# Altium schematic sheet Modules.SchDoc(Modules)
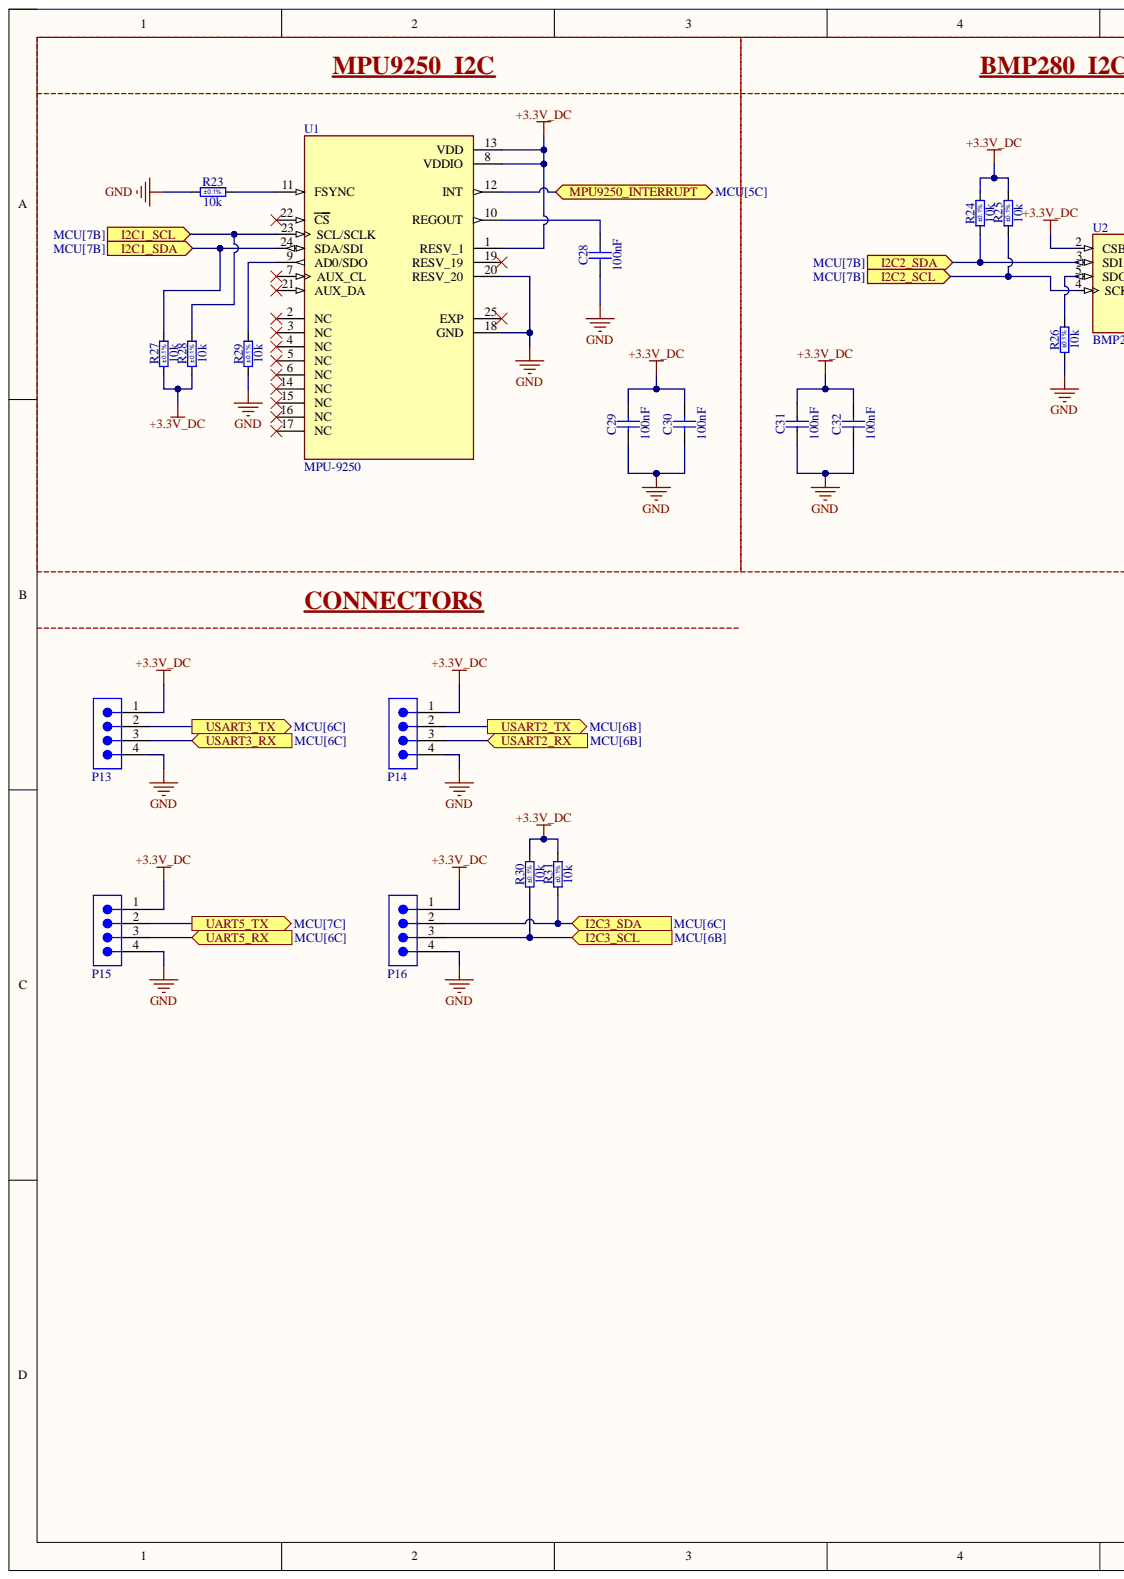
[diagram p0: Modules.SchDoc, left]
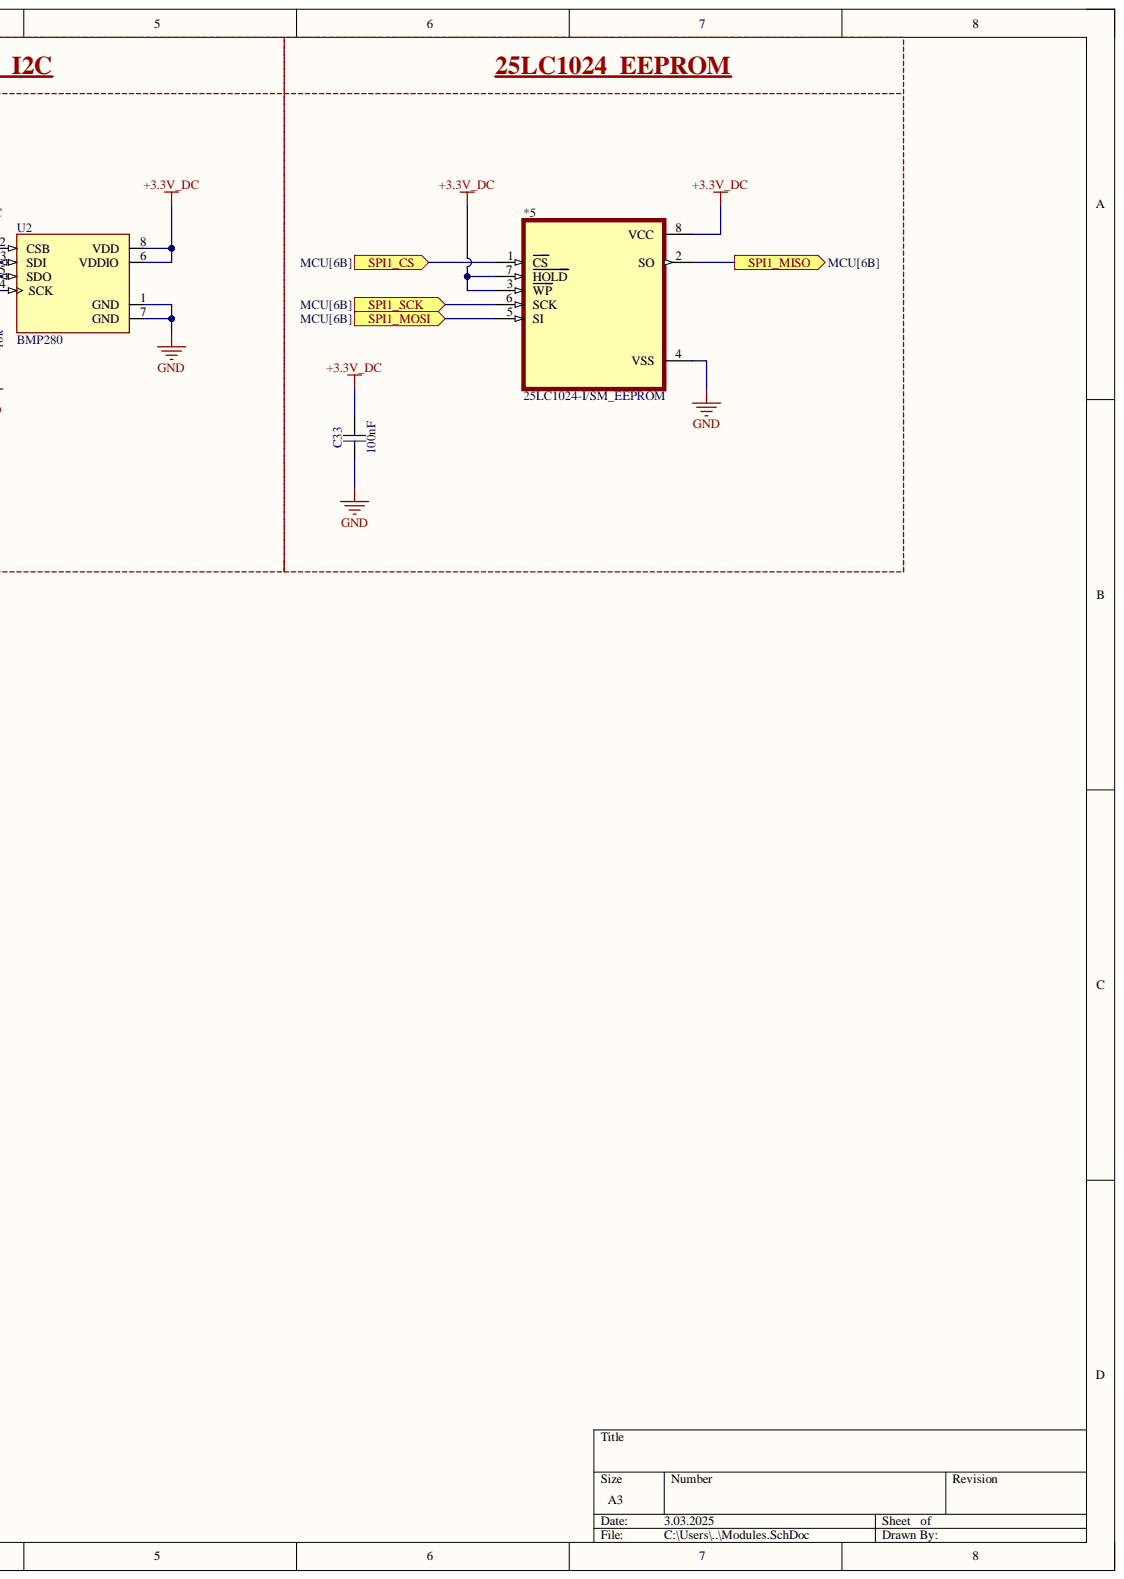
[diagram p1: Modules.SchDoc, right]

## Parts
*5  25LC1024-I/SM_EEPROM  [25LC1024-I/SM_EEPROM]
C28  100nF  [CAPC2012X135N]
C29  100nF  [CAPC2012X135N]
C30  100nF  [CAPC2012X135N]
C31  100nF  [CAPC2012X135N]
C32  100nF  [CAPC2012X135N]
C33  100nF  [CAPC2012X135N]
P13  691322310004  [691322310004]  (value not drawn)
P14  691322310004  [691322310004]  (value not drawn)
P15  691322310004  [691322310004]  (value not drawn)
P16  691322310004  [691322310004]  (value not drawn)
R23  10k  Tolerance: ±0.1%  [RESC2012X60N]
R24  10k  Tolerance: ±0.1%  [RESC2012X60N]
R25  10k  Tolerance: ±0.1%  [RESC2012X60N]
R26  10k  Tolerance: ±0.1%  [RESC2012X60N]
R27  10k  Tolerance: ±0.1%  [RESC2012X60N]
R28  10k  Tolerance: ±0.1%  [RESC2012X60N]
R29  10k  Tolerance: ±0.1%  [RESC2012X60N]
R30  10k  Tolerance: ±0.1%  [RESC2012X60N]
R31  10k  Tolerance: ±0.1%  [RESC2012X60N]
U1  MPU-9250  [QFN40P300X300X105-25N]
U2  BMP280  [XDCR_BMP280]

## Ports
I2C1_SCL, I2C1_SDA, I2C2_SCL, I2C2_SDA, I2C3_SCL, I2C3_SDA, MPU9250_INTERRUPT, SPI1_CS, SPI1_MISO, SPI1_MOSI, SPI1_SCK, UART5_RX, UART5_TX, USART2_RX, USART2_TX, USART3_RX, USART3_TX

## Nets
+3.3V_DC: *5.3(WP) *5.7(HOLD) *5.8(VCC) C29.2 C30.2 C31.2 C32.2 C33.2 P13.1 P14.1 P15.1 P16.1 R24.2 R25.2 R27.1 R28.1 R30.2 R31.2 U1.1(RESV_1) U1.8(VDDIO) U1.13(VDD) U2.2(CSB) U2.6(VDDIO) U2.8(VDD)
GND: *5.4(VSS) C28.1 C29.1 C30.1 C31.1 C32.1 C33.1 P13.4 P14.4 P15.4 P16.4 R23.1 R26.1 R29.1 U1.18(GND) U1.20(RESV_20) U2.1(GND) U2.7(GND)
I2C1_SCL: R28.2 U1.23(SCL/SCLK) ; port I2C1_SCL
I2C1_SDA: R27.2 U1.24(SDA/SDI) ; port I2C1_SDA
I2C2_SCL: R25.1 U2.4(SCK) ; port I2C2_SCL
I2C2_SDA: R24.1 U2.3(SDI) ; port I2C2_SDA
I2C3_SCL: P16.3 R30.1 ; port I2C3_SCL
I2C3_SDA: P16.2 R31.1 ; port I2C3_SDA
MPU9250_INTERRUPT: U1.12(INT) ; port MPU9250_INTERRUPT
SPI1_CS: *5.1(CS) ; port SPI1_CS
SPI1_MISO: *5.2(SO) ; port SPI1_MISO
SPI1_MOSI: *5.5(SI) ; port SPI1_MOSI
SPI1_SCK: *5.6(SCK) ; port SPI1_SCK
UART5_RX: P15.3 ; port UART5_RX
UART5_TX: P15.2 ; port UART5_TX
USART2_RX: P14.3 ; port USART2_RX
USART2_TX: P14.2 ; port USART2_TX
USART3_RX: P13.3 ; port USART3_RX
USART3_TX: P13.2 ; port USART3_TX
NetC28_2: C28.2 U1.10(REGOUT)
NetR23_2: R23.2 U1.11(FSYNC)
NetR26_2: R26.2 U2.5(SDO)
NetR29_2: R29.2 U1.9(AD0/SDO)

Not connected: U1 2(NC) 3(NC) 4(NC) 5(NC) 6(NC) 7(AUX_CL) 14(NC) 15(NC) 16(NC) 17(NC) 19(RESV_19) 21(AUX_DA) 22(CS) 25(EXP)

## Library data of the parts
C28-C33 = CC0805_100NF_50V_10%_X7R: Non-polarised capacitor; Manufacturer: GENERIC; Manufacturer1 Part Number: C2012X7R1H104K085; Part Description: SMD Multilayer Chip Ceramic Capacitor; Database Table Name: Capacitors SMD; TC: X7R; Tolerance: ±10%; Voltage: 50V; Case: 0805; Component Kind: Standard; Component Type: Standard; CreateDate: 3.12.2007; HelpURL: \\cern.ch\dfs\Applications\Altium\Datasheets\CC0805_X7R_TDK_C.pdf; LatestRevisionDate: 22.04.2015; Library Name: Capacitors.DbLib; Manufacturer1 ComponentHeight: 1mm; Manufacturer1 Example: TDK; Manufacturer Part Number: CC0805_100NF_50V_10%_X7R; Mounted: Yes; Part Number: CC0805_100NF_50V_10%_X7R; Pin Count: 2; Sense: No; SMD: Yes; Socket: No; Status: None; Value: 100nF; Author: CERN DEM JLC
P13-P16 = 691322310004: WR-TBL Series 322 Horizontal PCB Header, THT, pitch 3.81mm, 4p; Manufacturer: Wurth Elektronik; Database Table Name: Connectors; Modularity: No; Rated Current: 10A; Working Voltage: 300V; Application: Pluggable; Category: Terminal Blocks; Component Kind: Standard; ComponentLink1Description: Website Link; ComponentLink1URL: https://www.we-online.com/redexpert/article/691322310004?ad; ComponentLink2Description: Datasheet Link; ComponentLink2URL: https://www.we-online.com/redexpert/spec/691322310004?ad; Library Name: WE - Electromechanical Components.DbLib; Manufacturer Part Number: 691322310004; Match Code: WR-TBL; Mount: Through Hole; Number of Pins: 4; Number of Rows: 1; Operating Temperature Max: 105°C; Operating Temperature Min: -40°C; Orientation: Horizontal; Packaging: Box; Pitch: 3.81mm
R23-R31 = R0805_10K_0.1%_0.1W_10PPM: Resistor - 0.1%; Manufacturer: GENERIC; Manufacturer1 Part Number: RN73C2A10KBTG; Part Description: Thin Film Chip Resistor; Database Table Name: Resistors SMD; Power: 0.1W; PressFit: No; TC: ±10ppm; Case: 0805; Component Kind: Standard; Component Type: Standard; CreateDate: 3.12.2007; HelpURL: \\cern.ch\dfs\Applications\Altium\Datasheets\R0805_Tyco_RN73.pdf; LatestRevisionDate: 13.03.2008; Library Name: Resistors.DbLib; Manufacturer1 ComponentHeight: 0.6mm; Manufacturer1 Example: TYCO HOLSWORTHY; Manufacturer Part Number: R0805_10K_0.1%_0.1W_10PPM; Mounted: Yes; Part Number: R0805_10K_0.1%_0.1W_10PPM; Pin Count: 2; Sense: No; SMD: Yes; Socket: No; Status: Not Recommended; Value: 10k; Author: CERN DEM JLC
U1 = MPU-9250: MPU-9250 series Accelerometer, Gyroscope, Humidity, Magnetometer, Pressure, Temperature Sensor Evaluation Board; MF: TDK InvenSense; Check_prices: https://www.snapeda.com/parts/MPU-9250/TDK+InvenSense/view-part/?ref=eda; Component Kind: Standard; Library Name: MPU-9250.IntLib; MP: MPU-9250; Package: TFQFN-24 TDK InvenSense; SnapEDA_Link: https://www.snapeda.com/parts/MPU-9250/TDK+InvenSense/view-part/?ref=snap
U2 = BMP280: BMP280 Absolute Barometric Pressure Sensor for Battery Powered Devices 2 x 2.5mm; Availability: Unavailable; Component Kind: Standard; Library Name: BMP280.IntLib; MP: BMP280; Package: None; Price: None
*5 = 25LC1024-I/SM_EEPROM: Component Kind: Standard; Library Name: UDEMY_Library.IntLib
